# Altium schematic sheet Power-ATX.SchDoc(Power-ATX)
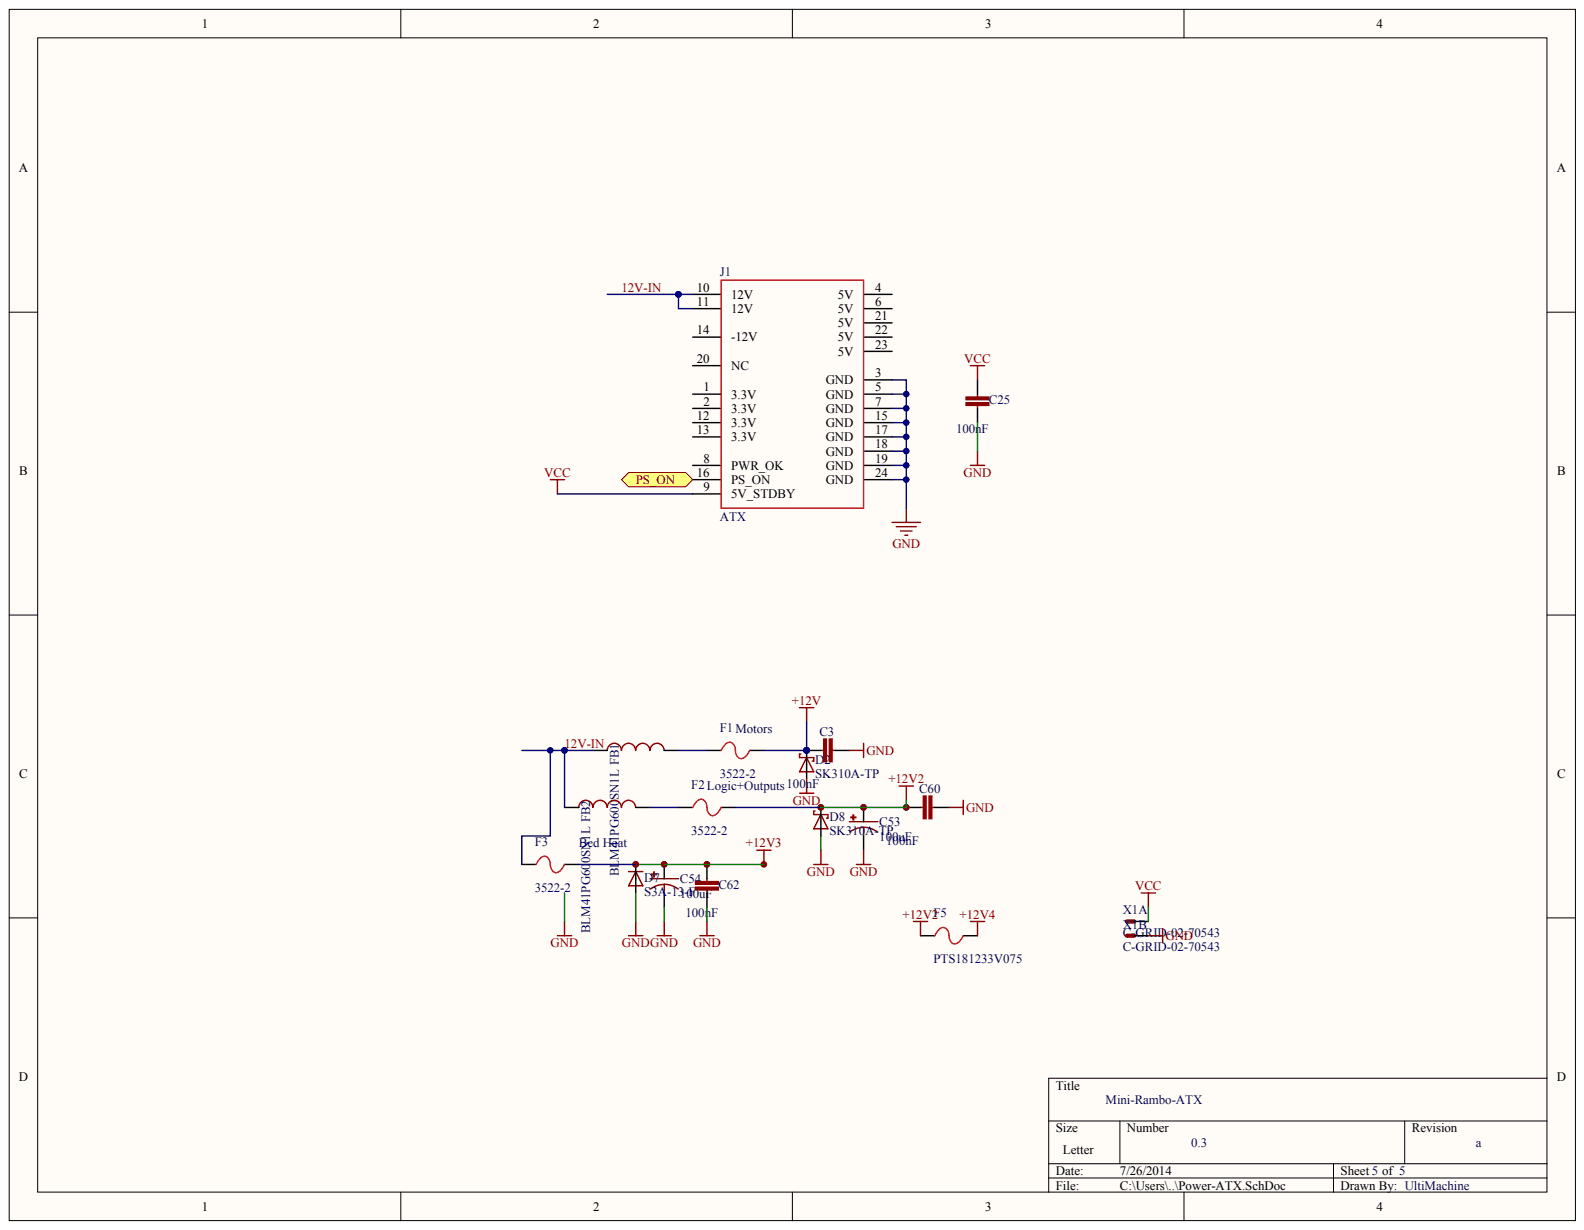
[diagram p0: Power-ATX.SchDoc]

## Parts
C3  100nF
C25  100nF
C53  100uF
C54  100uF
C60  100nF
C62  100nF
D2  SK310A-TP
D7  S3A-13-F
D8  SK310A-TP
F1  3522-2
F2  3522-2
F3  3522-2
F5  PTS181233V075
FB1  BLM41PG600SN1L
FB2  BLM41PG600SN1L
J1  ATX
X1  C-GRID-02-70543  (parts A,B)

## Ports
PS_ON

## Nets
+12V: C3.1 D2.C F1.2
+12V2: C53.1 C60.1 D8.C F2.2 F5.1
+12V3: C54.1 C62.1 D7.C F3.2
+12V4: F5.2
GND: C3.2 C25.2 C53.2 C54.2 C60.2 C62.2 D2.A D7.A D8.A J1.3(GND) J1.5(GND) J1.7(GND) J1.15(GND) J1.17(GND) J1.18(GND) J1.19(GND) J1.24(GND) X1.1
VCC: C25.1 J1.9(5V_STDBY) X1.2
12V-IN: F3.1 FB1.1 FB2.1 J1.10(12V) J1.11(12V)
PS_ON: J1.16(PS_ON) ; port PS_ON
NetF1_1: F1.1 FB1.2
NetF2_1: F2.1 FB2.2

Not connected: J1 1(3.3V) 2(3.3V) 4(5V) 6(5V) 8(PWR_OK) 12(3.3V) 13(3.3V) 14(-12V) 20(NC) 21(5V) 22(5V) 23(5V)

## Library data of the parts
C3 C25 C60 C62 = (no symbol name): ManufacturerPartNumber: C0603C104K5RACTU; LastRevisionNote: ImportComponents; Published: 23-Apr-2014; LastRevisionDate: 23-Apr-2014; VALUE: 100nF; Publisher: UltiMachine
C53 C54 = (no symbol name): ManufacturerPartNumber: UVZ1H101MPD1TD; LastRevisionNote: ImportComponents; Published: 23-Apr-2014; LastRevisionDate: 23-Apr-2014; VALUE: 100uF; Publisher: UltiMachine
D2 D8 = (no symbol name): ManufacturerPartNumber: SMAJ30A; LastRevisionNote: ImportComponents; LastRevisionDate: 23-Apr-2014; Published: 23-Apr-2014; Publisher: UltiMachine
D7 F1-F3 F5 FB1 FB2 X1 = (no symbol name): LastRevisionNote: ImportComponents; Published: 23-Apr-2014; LastRevisionDate: 23-Apr-2014; Publisher: UltiMachine
  D7: Value: S3A-13-F
  F1-F3: ManufacturerPartNumber: 3522-2
  F5: ManufacturerPartNumber: PTS181233V075
  FB1 FB2: ManufacturerPartNumber: BLM41PG600SN1L
